# Altium schematic sheet Power.SchDoc
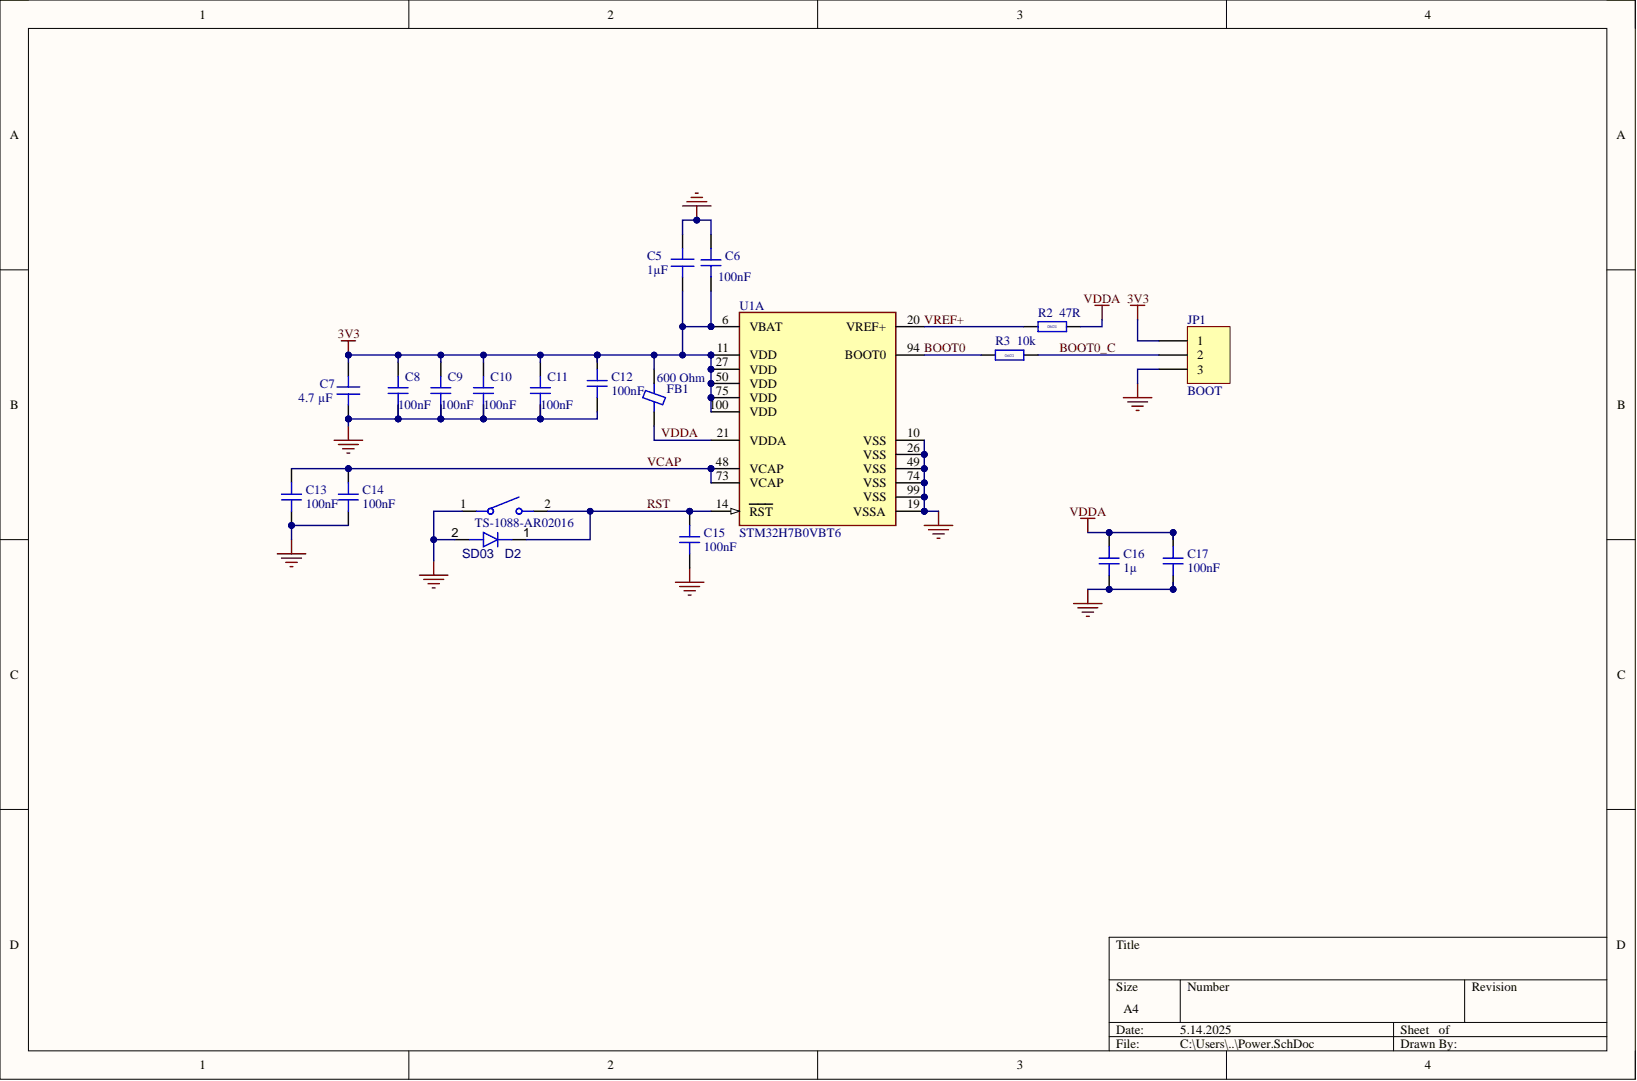
[diagram p0: Power.SchDoc]

## Parts
C5  value 1µF  Capacitance: 1µF  [CAP 0805_2012]  (hidden comment: 1u)
C6  100nF  [CAP_0402]
C7  value 4.7 µF  Capacitance: 4.7 µF  [CAP 0805_2012]  (hidden comment: 4u7)
C8  100nF  [CAP_0402]
C9  100nF  [CAP_0402]
C10  100nF  [CAP_0402]
C11  100nF  [CAP_0402]
C12  100nF  [CAP_0603]
C13  100nF  [CAP_0402]
C14  100nF  [CAP_0402]
C15  100nF  [CAP_0603]
C16  1µ  [CAP_0603]
C17  100nF  [CAP_0402]
D2  SD03  [SD03]
FB1  600 Ohm  [FER 0603_1608]
JP1  BOOT  [HDR1X3]
R2  47R  [RES_0603]
R3  10k  [RES_0603]
SW1  TS-1088-AR02016  [TS-1088-AR02016-Footprint-1]
U1  STM32H7B0VBT6  [FP-LQFP100-IPC_A]  (part A)

## Nets
3V3: C5.1 C6.2 C7.2 C8.2 C9.2 C10.2 C11.2 C12.2 FB1.1 JP1.1 U1.6(VBAT) U1.11(VDD) U1.27(VDD) U1.50(VDD) U1.75(VDD) U1.100(VDD)
GND: C5.2 C6.1 C7.1 C8.1 C9.1 C10.1 C11.1 C12.1 C13.1 C14.1 C15.1 C16.1 C17.1 D2.2 JP1.3 SW1.1 U1.10(VSS) U1.19(VSSA) U1.26(VSS) U1.49(VSS) U1.74(VSS) U1.99(VSS)
BOOT0: R3.1 U1.94(BOOT0)
BOOT0_C: JP1.2 R3.2
RST: C15.2 D2.1 SW1.2 U1.14(RST)
VCAP: C13.2 C14.2 U1.48(VCAP) U1.73(VCAP)
VDDA: C16.2 C17.2 FB1.2 R2.2 U1.21(VDDA)
VREF+: R2.1 U1.20(VREF+)

## Library data of the parts
C5 = MM05ZC105KCZ2A: CAP CER 1UF 10V X7R 0805; manufacturer: AVX Corporation; Database Table Name: Capacitors - Ceramic - 0805; Tolerance: ±10%; Applications: Medical, Non-Critical; Component Kind: Standard; componentlink1description: Datasheet; componentlink1url: http://datasheets.avx.com/AVX-MM.pdf; componentlink2description: DigiKey Link; componentlink2url: http://digikey.com/product-detail/en/avx-corporation/MM05ZC105KCZ2A/478-10611-1-ND/7344872; Device Package: 0805 (2012 Metric); lastupdated: 02.09.2020 01:04:42; Library Name: Celestial Altium Library - altium_library.DbLib; Lifecycle Status: Active; Minimum Order: 1; Mounting Type: Surface Mount, MLCC; Operating Temperature: -55°C ~ 125°C; Package / Case: 0805 (2012 Metric); packaging: TapeAndReel; Part Number: MM05ZC105KCZ2A; Part Status: Active; partid: 2439; price: 0.50; series: MM; Size / Dimension: 0.079" L x 0.049" W (2.01mm x 1.25mm); Supplier 1: DigiKey; Supplier Part Number 1: 478-10611-1-ND; Temperature Coefficient: X7R; Thickness (Max): 0.029" (0.74mm); Voltage - Rated: 10V
C6 C8-C11 C13 C14 C17 = CMP-001-00085-1: Capacitor SMD 0402; Component Kind: Standard; Design Item ID: CAP_0402; Library Name: Simon Mayr; Source: Schematic-Lib-PhilsLab.SchLib
C7 = C0805X475M3RAC7800: 4.70UF 25.0V; manufacturer: KEMET; Database Table Name: Capacitors - Ceramic - 0805; Temperature Coefficient: X7R; Tolerance: ±20%; Voltage - Rated: 25V; Applications: Boardflex Sensitive; Component Kind: Standard; componentlink1description: Datasheet; componentlink1url: https://connect.kemet.com:7667/gateway/IntelliData-ComponentDocumentation/1.0/download/datasheet/C0805X475M3RAC7800; componentlink2description: DigiKey Link; componentlink2url: https://www.digikey.com/en/products/detail/kemet/C0805X475M3RAC7800/12082671; Device Package: 0805 (2012 Metric); Features: Soft Termination; lastupdated: 09.02.2024 20:14:56; Library Name: Celestial Altium Library - altium_library.DbLib; Lifecycle Status: Active; Minimum Order: 1; Mounting Type: Surface Mount, MLCC; Operating Temperature: -55°C ~ 125°C; Package / Case: 0805 (2012 Metric); packaging: TapeAndReel; Part Number: C0805X475M3RAC7800; Part Status: Active; partid: 46494; price: 0.14; series: SMD Comm X7R Flex; Size / Dimension: 0.079" L x 0.049" W (2.00mm x 1.25mm); Supplier 1: DigiKey; Supplier Part Number 1: 399-C0805X475M3RAC7800CT-ND; Thickness (Max): 0.057" (1.45mm)
C12 C15 C16 = CMP-001-00086-1: Capacitor SMD 0603; Component Kind: Standard; Design Item ID: CAP_0603; Library Name: Simon Mayr; Source: Schematic-Lib-PhilsLab.SchLib
D2 = CMP-011-00015-3: 20A 6.5V 10.5V Unidirectional 4V SOD-323 ESD and Surge Protection (TVS/ESD) ROHS; Component Kind: Standard; ComponentLink1Description: LCSC Link; ComponentLink1URL: https://www.lcsc.com/product-detail/ESD-and-Surge-Protection-TVS-ESD_LGE-SD03_C5369353.html; Library Name: Simon Mayr
FB1 = BLM18BD601BH1D: FERRITE BEAD 600 OHM 0603 1LN; Manufacturer: Murata Electronics; Current Rating (Max): 200mA; Database Table Name: Filters - Ferrite Beads and Chips; DC Resistance (DCR) (Max): 650mOhm; Filter Type: Power Line; Impedance @ Frequency: 600 Ohms @ 100 MHz; Number of Lines: 1; Value: 600 Ohms @ 100 MHz; Component Kind: Standard; ComponentLink1Description: Datasheet; ComponentLink1URL: https://www.murata.com/en-us/products/productdata/8798137286686/QNFA9129.pdf; ComponentLink2Description: DigiKey Link; ComponentLink2URL: https://www.digikey.com/en/products/detail/murata-electronics/BLM18BD601BH1D/6156023; Device Package: 0603 (1608 Metric); Height (Max): 0.037" (0.95mm); LastUpdated: 03.06.2024 19:51:56; Library Name: Celestial Altium Library - altium_library.DbLib; Lifecycle Status: Active; Minimum Order: 1; Mounting Type: Surface Mount; Operating Temperature: -55°C ~ 150°C; Package / Case: 0603 (1608 Metric); Packaging: TapeAndReel; Part Number: BLM18BD601BH1D; Part Status: Active; PartId: 556; Price: 0.03; Ratings: AEC-Q200; Series: EMIFIL®, BLM18; Size / Dimension: 0.063" L x 0.032" W (1.60mm x 0.80mm); Supplier 1: DigiKey; Supplier Part Number 1: 490-14052-1-ND
JP1 = CMP-002-00208-1: Header, 3-Pin; Component Kind: Standard; Design Item ID: Header 3; LatestRevisionDate: 17-Jul-2002; LatestRevisionNote: Re-released for DXP Platform.; Source: Miscellaneous Connectors.SchLib; Type: Connector; Library Name: Simon Mayr; Publisher: Altium Limited
R2 R3 = CMP-009-00189-1: Resistor SMD 0603; Component Kind: Standard; Design Item ID: RES_0603; Library Name: Simon Mayr; Source: Schematic-Lib-PhilsLab.SchLib
SW1 = CMP-011-00014-2: Without 50mA 4mm 100MΩ 100000 Times 12V 160gf 3mm 2mm Round Button Standing paste SPST SMD Tactile Switches ROHS; Component Kind: Standard; Pins: 2; Library Name: Simon Mayr
U1 = CMP-020-00023-1: RISC Microcontroller, 32-Bit, FLASH, CORTEX-M7 CPU, 280MHz, CMOS, PQFP100; Component Kind: Standard; Library Name: Simon Mayr
